# Altium schematic sheet MICROPHONE.SchDoc
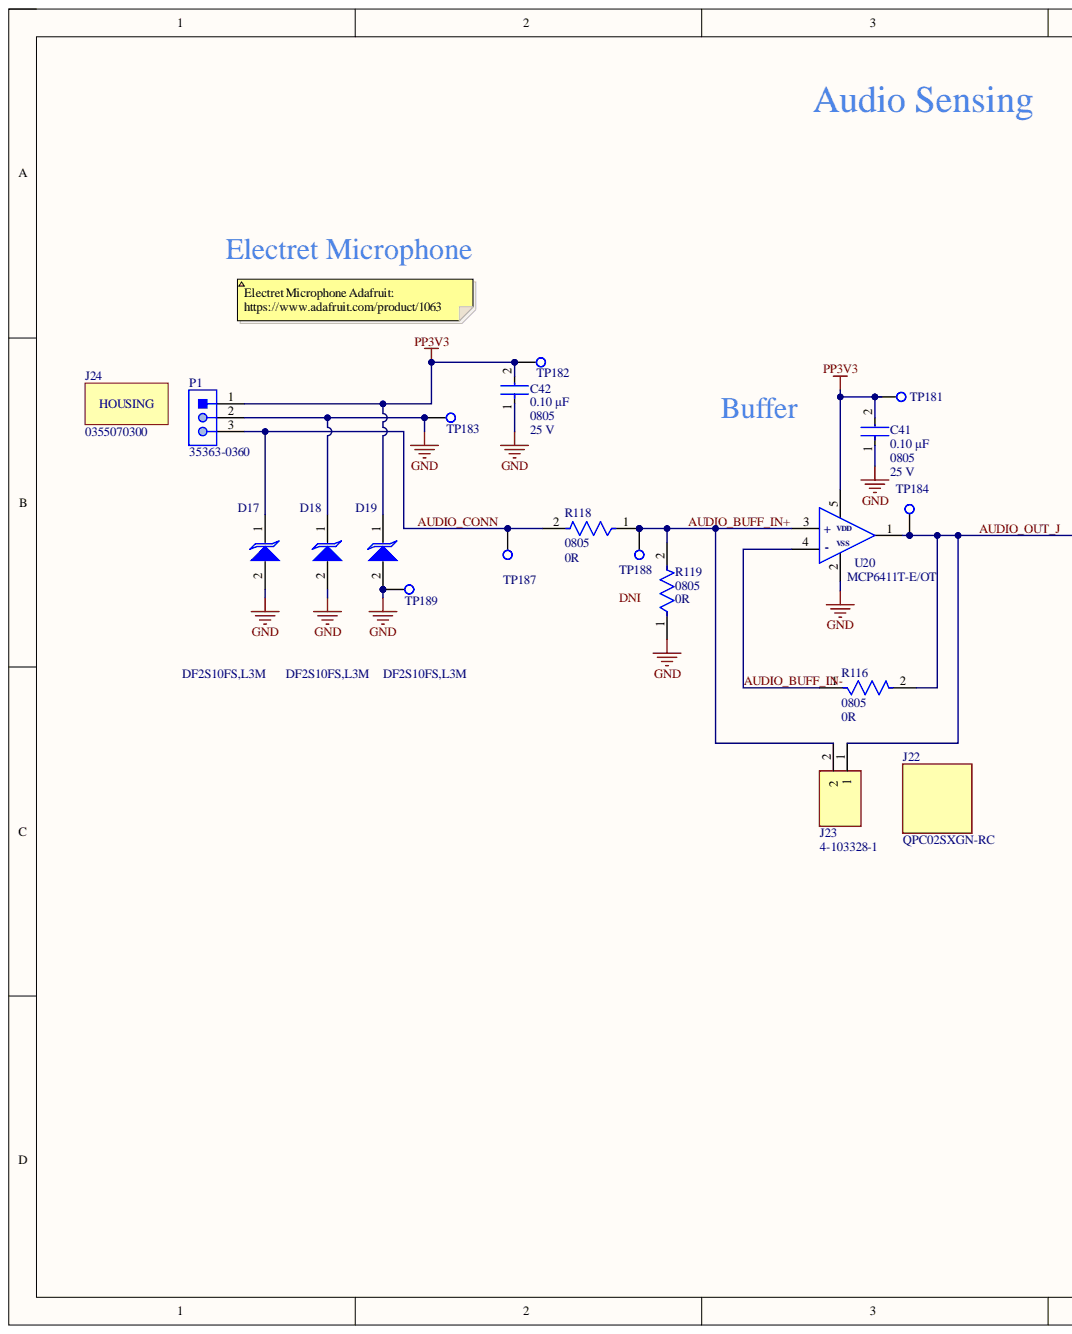
[diagram p0: MICROPHONE.SchDoc, left]
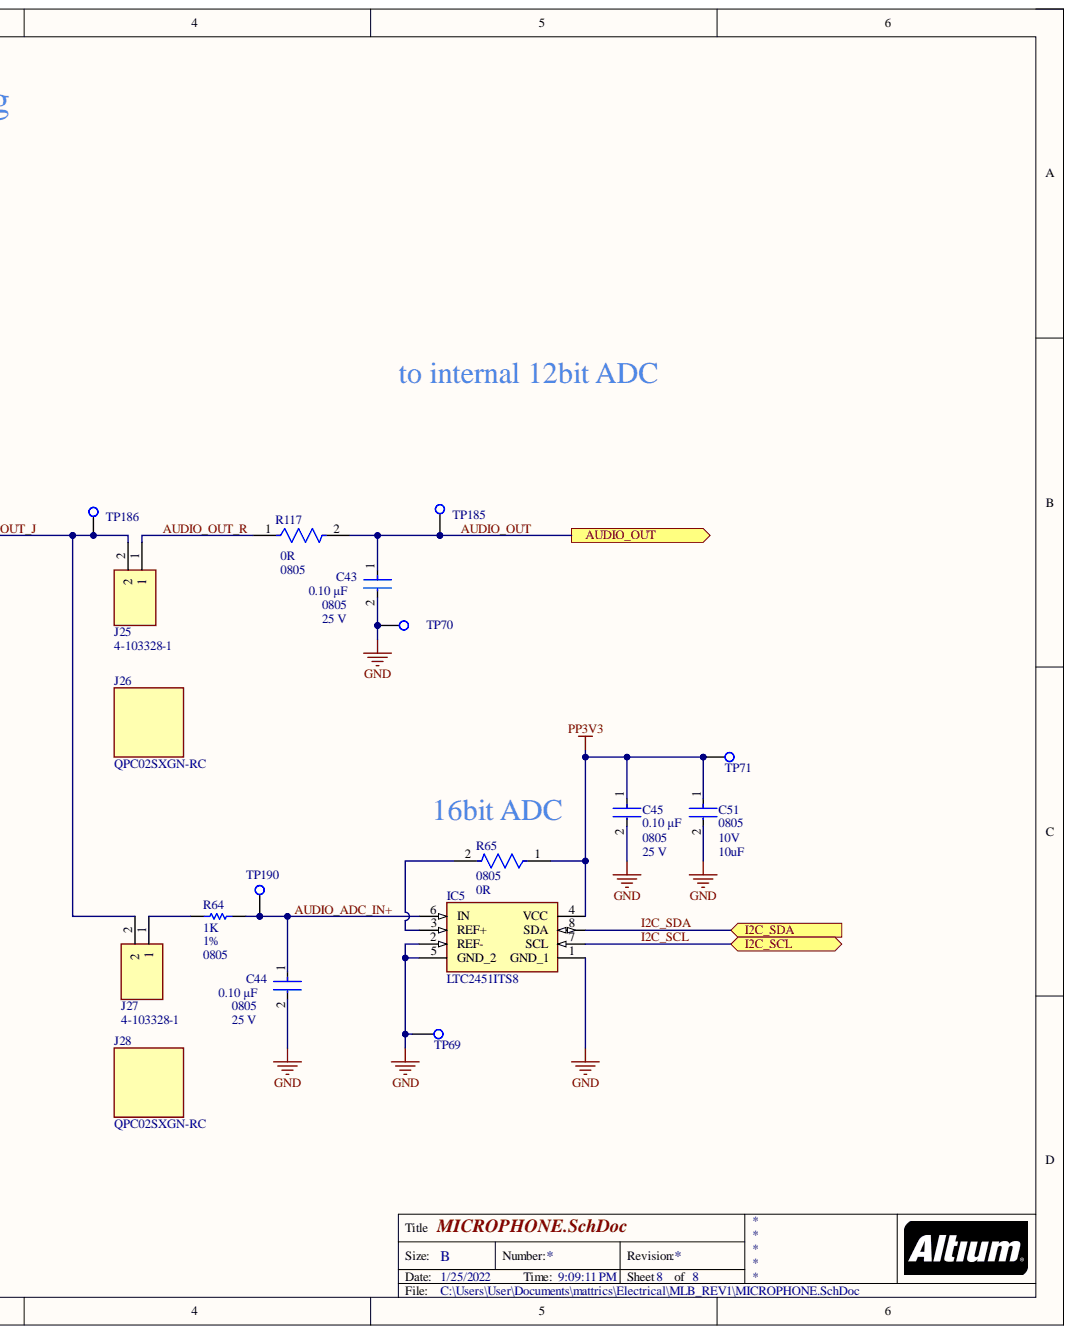
[diagram p1: MICROPHONE.SchDoc, right]

## Parts
C41  value 0.10 μF  Capacitance: 0.10 μF  Package: 0805  [FP-C0805C-DN-MFG]  (hidden comment: C0805C104M3RACTU)
C42  value 0.10 μF  Capacitance: 0.10 μF  Package: 0805  Voltage Rating: 25 V  [FP-C0805C-DN-MFG]  (hidden comment: C0805C104M3RACTU)
C43  value 0.10 μF  Capacitance: 0.10 μF  Package: 0805  Voltage Rating: 25 V  [FP-C0805C-DN-MFG]  (hidden comment: C0805C104M3RACTU)
C44  value 0.10 μF  Package: 0805  Voltage Rating: 25 V  [FP-C0805C-DN-MFG]  (hidden comment: C0805C104M3RACTU)
C45  value 0.10 μF  Capacitance: 0.10 μF  Package: 0805  Voltage Rating: 25 V  [FP-C0805C-DN-MFG]  (hidden comment: C0805C104M3RACTU)
C51  Capacitance: 10uF  Case/Package: 0805  Voltage Rating (DC): 10V  [FP-GRM21B-0_15-IPC_A]  (hidden comment: GRM21BR71A106KA73K)
D17  DF2S10FS,L3M  [DIOM106X45N]
D18  DF2S10FS,L3M  [DIOM106X45N]
D19  DF2S10FS,L3M  [DIOM106X45N]
IC5  LTC2451ITS8  [SOT65P280X100-8N]
J22  QPC02SXGN-RC  [FP-QPC02SXGN-RC-MFG]
J23  4-103328-1  [TE_4-103328-1]
J24  0355070300
J25  4-103328-1  [TE_4-103328-1]
J26  QPC02SXGN-RC  [FP-QPC02SXGN-RC-MFG]
J27  4-103328-1  [TE_4-103328-1]
J28  QPC02SXGN-RC  [FP-QPC02SXGN-RC-MFG]
P1  35363-0360  [MOLX-35363-0360_V]
R64  Case Code (Imperial): 0805  Resistance: 1K  Tolerance: 1%  [RESC2013X60X35ML10T20]  (hidden comment: RC0805FR-071KL)
R65  Case Code (Imperial): 0805  [FP-RMCF0805-MFG]  (hidden comment: RMCF0805ZT0R00)
R116  Case Code (Imperial): 0805  Resistance: 0R  [FP-RMCF0805-MFG]  (hidden comment: RMCF0805ZT0R00)
R117  Case Code (Imperial): 0805  Resistance: 0R  [FP-RMCF0805-MFG]  (hidden comment: RMCF0805ZT0R00)
R118  Case Code (Imperial): 0805  Resistance: 0R  [FP-RMCF0805-MFG]  (hidden comment: RMCF0805ZT0R00)
R119  Case Code (Imperial): 0805  Resistance: 0R  [FP-RMCF0805-MFG]  (hidden comment: RMCF0805ZT0R00)
TP69  RCU-0C  [TP+195X110]  (value not drawn)
TP70  RCU-0C  [TP+195X110]  (value not drawn)
TP71  RCU-0C  [TP+195X110]  (value not drawn)
TP181  RCU-0C  [TP+195X110]  (value not drawn)
TP182  RCU-0C  [TP+195X110]  (value not drawn)
TP183  RCU-0C  [TP+195X110]  (value not drawn)
TP184  RCU-0C  [TP+195X110]  (value not drawn)
TP185  RCU-0C  [TP+195X110]  (value not drawn)
TP186  RCU-0C  [TP+195X110]  (value not drawn)
TP187  RCU-0C  [TP+195X110]  (value not drawn)
TP188  RCU-0C  [TP+195X110]  (value not drawn)
TP189  RCU-0C  [TP+195X110]  (value not drawn)
TP190  RCU-0C  [TP+195X110]  (value not drawn)
U20  MCP6411T-E/OT  [SOT95P280X145-5N]

## Ports
AUDIO_OUT, I2C_SCL, I2C_SDA

## Nets
GND: C41.1 C42.1 C43.2 C44.2 C45.2 C51.2 D17.2 D18.1 D18.2 D19.2 IC5.1(GND_1) IC5.2(REF-) IC5.5(GND_2) P1.2 R119.1 TP69.1 TP70.1 TP183.1 TP189.1 U20.2
PP3V3: C41.2 C42.2 C45.1 C51.1 D19.1 IC5.4(VCC) P1.1 R65.1 TP71.1 TP181.1 TP182.1 U20.5
AUDIO_ADC_IN+: C44.1 IC5.6(IN) R64.1 TP190.1
AUDIO_BUFF_IN+: J23.2 R118.1 R119.2 TP188.1 U20.3(+)
AUDIO_BUFF_IN-: R116.1 U20.4(-)
AUDIO_CONN: D17.1 P1.3 R118.2 TP187.1
AUDIO_OUT: C43.1 R117.2 TP185.1 ; port AUDIO_OUT
AUDIO_OUT_J: J23.1 J25.2 J27.2 R116.2 TP184.1 TP186.1 U20.1
AUDIO_OUT_R: J25.1 R117.1
I2C_SCL: IC5.7(SCL) ; port I2C_SCL
I2C_SDA: IC5.8(SDA) ; port I2C_SDA
NetIC5_3: IC5.3(REF+) R65.2
NetJ27_1: J27.1 R64.2

## Library data of the parts
C41-C45 = CMP-2007-00282-2: CAP CER 0.1UF 25V 20% X7R 0805; Manufacturer: KEMET; Material: Ceramic; Temperature Coefficient: 30ppm/°C; Tolerance: 0.2; Automotive: No; Category: Cap; Component Kind: Standard; ComponentLink2Description: Datasheet; ComponentLink2URL: https://content.kemet.com/datasheets/KEM_C1003_C0G_SMD.pdf; Device Class L1: Passive Components; Device Class L2: Capacitors; Device Class L3: Ceramic Capacitors; Digikey Description: CAP CER 0.1UF 25V 20% X7R 0805; Height: 0.88mm; Ipc Land Pattern Name: CAPC200125X78; Lead Free: Yes; Library Name: Altium Content Vault; Manufacturer Part Number: C0805C104M3RACTU; Mouser Description: Multilayer Ceramic Capacitors MLCC - SMD/SMT 25volts 0.1uF X7R 20%; Octopart: https://octopart.com/c0805c104m3ractu-kemet-9138612; RoHS: TRUE; Supplier: Digi-Key; Supplier Part Number: 399-C0805C104M3RAC7800CT-ND; Temperature: -55°C to +125°C; Temperature Characteristic: X7R; Temperature Range High: +125°C; Temperature Range Low: -55°C
  C41: Voltage Rating: 25 V
  C44: Capacitance: 0.10 μF
C51 = CMP-06035-038134-1: Chip Multilayer Ceramic Capacitors for General Purpose, 0805, 10uF, X7R, 15%, 10%, 10V; Manufacturer: Murata Electronics; Component Kind: Standard; Dielectric: X7R; Length: 2mm; Library Name: Altium Content Vault; Manufacturer Part Number: GRM21BR71A106KA73K; Supplier: Digi-Key; Supplier Part Number: 490-14381-1-ND; Thickness: 1.25mm; Tolerance: 10%; Width: 1.25mm
D17-D19 = DF2S10FS,L3M: TVS DIODE 8VWM FSC; Manufacturer: Toshiba Semiconductor and Storage; Manufacturer_Name: Toshiba; Arrow Part Number: DF2S10FS,L3M; Arrow Price/Stock: https://www.arrow.com/en/products/df2s10fsl3m/toshiba?region=nac; Component Kind: Standard; Datasheet Link: https://componentsearchengine.com/Datasheets/1/DF2S10FS,L3M.pdf; Height: 0.45mm; Library Name: MLBSchLib.SchLib; Manufacturer Part Number: DF2S10FS,L3M; Manufacturer_Part_Number: DF2S10FS,L3M; Mouser Part Number: 757-DF2S10FSL3M; Mouser Price/Stock: https://www.mouser.co.uk/ProductDetail/Toshiba/DF2S10FSL3M?qs=lhkzy8lC%252BSsM5fAm2E7mCQ%3D%3D; Supplier: Digi-Key; Supplier Part Number: DF2S10FSL3MCT-ND
IC5 = LTC2451ITS8#TRMPBF: IC ADC 16BIT SIG-DELTA TSOT23-8; Manufacturer: Analog Devices Inc.; Manufacturer Part Number: LTC2451ITS8#TRPBF; Arrow Part Number: LTC2451ITS8#TRMPBF; Arrow Price/Stock: https://www.arrow.com/en/products/ltc2451its8trmpbf/linear-technology; Component Kind: Standard; Datasheet Link: http://www.farnell.com/datasheets/1705581.pdf?_ga=2.21625278.449712991.1504041739-494487131.1503310922; Height: 1mm; Library Name: MLBSchLib.SchLib; Mouser Part Number: 584-C2451ITS8TRMPBF; Mouser Price/Stock: https://www.mouser.com/Search/Refine.aspx?Keyword=584-C2451ITS8TRMPBF; Supplier: Digi-Key; Supplier Part Number: 505-LTC2451ITS8#TRPBFCT-ND
J22 J26 J28 = CMP-25666-000001-1: CONN JUMPER SHORTING .100" GOLD; Manufacturer: Sullins; Conn Orientation: Straight; Current Rating: 3A; Number Of Contacts: 2; Pitch: 2.54mm; Automotive: No; Category: Conn; Component Kind: Standard; ComponentLink2Description: Datasheet; ComponentLink2URL: https://s3.amazonaws.com/catalogspreads-pdf/PAGE128-129%20.100%20JUMPER.pdf; Contact Material: Gold; Device Class L1: Connectors; Device Class L2: unset; Device Class L3: unset; Digikey Description: CONN JUMPER SHORTING .100" GOLD; Footprint Url: https://drawings-pdf.s3.amazonaws.com/11510.pdf; Height: 6.17mm; Lead Free: Yes; Library Name: Altium Content Vault; Manufacturer Part Number: QPC02SXGN-RC; Octopart: https://octopart.com/qpc02sxgn-rc-sullins-19878795; Package: 0; RoHS: TRUE; Supplier: Digi-Key; Supplier Part Number: S9337-ND; Temperature: -40°C to +85°C; Temperature Range High: +85°C; Temperature Range Low: -40°C
J23 J25 J27 = 4-103328-1: Connector Header Through Hole 2 position; Manufacturer: TE Connectivity AMP Connectors; MF: TE Connectivity; Availability: Unavailable; Component Kind: Standard; EU_RoHS_Compliance: Compliant; Library Name: MLBSchLib.SchLib; Manufacturer Part Number: 4-103328-1; MP: 4-103328-1; Package: None; Price: None; SnapEDA_Link: https://www.snapeda.com/parts/4-103328-1/TE%20Connectivity%20AMP%20Connectors/view-part/2384420/?ref=snap; Supplier: Digi-Key; Supplier Part Number: A121364-ND; TE_purchase_URL: https://www.te.com/usa-en/product-4-103328-1.html?te_bu=Cor&te_type=disp&te_campaign=seda_glo_cor-seda-global-disp-prtnr-fy19-seda-model-bom-cta_sma-317_1&elqCampaignId=32493
J24 = 0355070300: Manufacturer: Molex; Component Kind: Standard; Library Name: MLBSchLib.SchLib; Manufacturer Part Number: 0355070300; Supplier: Digi-Key; Supplier Part Number: WM5982-ND
P1 = CMP-2000-05595-1: Male Header, Pitch 2 mm, 1 x 3 Position, Height 5.5 mm, Tail Length 3.2 mm, -40 to 105 degC, RoHS, Bulk; Manufacturer: Molex; Manufacturer Part Number: 0353630360; Gender: HDR; Number of Contacts: 3; Pitch: 2mm; Category: ConnectorHeadersandPCBReceptacles; Component Kind: Standard; Datasheet URL: http://www.molex.com/pdm_docs/sd/353630360_sd.pdf; Datasheet Version: Rev. G, 01/2012; Library Name: Altium Content Vault; Manufacturer URL: http://www.molex.com/; Mounting Technology: ThroughHole; Number of Rows: 1; Package Description: Male Header, Pitch 2 mm, 1 x 3 Position, Height 5.5 mm, Tail Length 3.2 mm; Package Reference: 35363-0360; Supplier: Digi-Key; Supplier Part Number: WM18932-ND; Termination Method: Solder; Type: WiretoBoard
R64 = CMP-2100-03671-1: Chip Resistor, 1 KOhm, +/- 1%, 125 mW, -55 to 155 degC, 0805 (2012 Metric), RoHS, Tape and Reel; Manufacturer: Yageo; Max Power Dissipation: 125mW; Temperature Coefficient: 100ppm/degreesC; Voltage Rating: 150V; Case Code (Metric): 2012; Case/Package: 0805; Component Kind: Standard; Composition: ThickFilm; Datasheet URL: http://www.yageo.com/NewPortal/yageodocoutput?fileName=/pdf/R-Chip/PYu-RC_Group_51_RoHS_L_5.pdf; Datasheet Version: Rev. 5, 10/2016; Depth: 1.25mm; Height: 0.024inch; Lead Free: LeadFree; Length: 0.079inch; Library Name: Altium Content Vault; Manufacturer Part Number: RC0805FR-071KL; Manufacturer URL: http://www.yageo.com/; Max Operating Temperature: 155degC; Military Standard: Not; Min Operating Temperature: -55degC; Number of Pins: 2; Number of Terminations: 2; Package Description: 2-Pin Surface Mounted Device, Body 2 x 1.25 mm; Package Quantity: 1; Package Reference: 0805; Packaging: TapeandReel; Power Rating: 125mW; REACH SVHC: Unknown; RoHSCompliant: true; Supplier: Digi-Key; Supplier Part Number: 311-1.00KCRCT-ND; Termination: SMD/SMT; Voltage Rating (DC): 150V; Width: 0.049inch
R65 = CMP-26527-000017-1: RES 0 OHM JUMPER 1/8W 0805; Manufacturer: Stackpole Electronics Inc; Max Power Dissipation: 125mW; Resistance: 0R; Case Code (Metric): 2012; Case/Package: 0805; Component Kind: Standard; Composition: Thick Film; Contact Plating: Tin; Depth: 1.25mm; Features: Automotive AEC-Q200; Height: 0.026inch; Lead Free: Lead Free; Length: 2mm; Library Name: Altium Content Vault; Manufacturer Part Number: RMCF0805ZT0R00; Max Operating Temperature: 155°C; Military Standard: Not; Min Operating Temperature: -55°C; Mount: Surface Mount; Number of Terminations: 2; Packaging: Tape and Reel; Power Rating: 125mW; Radiation Hardening: No; Supplier: Digi-Key; Supplier Part Number: RMCF0805ZT0R00CT-ND; Width: 0.049inch
R116-R119 = CMP-26527-000017-1: RES 0 OHM JUMPER 1/8W 0805; Manufacturer: Stackpole Electronics Inc; Max Power Dissipation: 125mW; Case Code (Metric): 2012; Case/Package: 0805; Component Kind: Standard; Composition: Thick Film; Contact Plating: Tin; Depth: 1.25mm; Features: Automotive AEC-Q200; Height: 0.026inch; Lead Free: Lead Free; Length: 2mm; Library Name: Altium Content Vault; Manufacturer Part Number: RMCF0805ZT0R00; Max Operating Temperature: 155°C; Military Standard: Not; Min Operating Temperature: -55°C; Mount: Surface Mount; Number of Terminations: 2; Packaging: Tape and Reel; Power Rating: 125mW; Radiation Hardening: No; Supplier: Digi-Key; Supplier Part Number: RMCF0805ZT0R00CT-ND; Width: 0.049inch
TP69-TP71 TP181-TP190 = RCU-0C: Natural PC Test Point , Plating Surface Mount Mounting Type; Manufacturer: TE Connectivity AMP Connectors; Check_prices: https://www.snapeda.com/parts/RCU-0C/TE%20Connectivity/view-part/4791537/?ref=eda; Component Kind: Standard; Library Name: MLBSchLib.SchLib; Manufacturer Part Number: RCU-0C; Package: SON-6 TE Connectivity AMP Connectors; Purchase-URL: https://pricing.snapeda.com/search/part/RCU-0C/?ref=eda; SnapEDA_Link: https://www.snapeda.com/parts/RCU-0C/TE%20Connectivity/view-part/4791537/?ref=snap; Supplier: Digi-Key; Supplier Part Number: A106145CT-ND
U20 = MCP6411T-E_OT: IC OPAMP GP 1 CIRCUIT SOT23-5; Manufacturer: Microchip; Arrow Part Number: MCP6411T-E/OT; Arrow Price/Stock: https://www.arrow.com/en/products/mcp6411t-eot/microchip-technology; Component Kind: Standard; Datasheet Link: http://ww1.microchip.com/downloads/en/DeviceDoc/20005791B.pdf; Height: 1.45mm; Library Name: MLBSchLib.SchLib; Manufacturer Part Number: MCP6411T-E/OT; Mouser Part Number: 579-MCP6411T-E/OT; Mouser Price/Stock: https://www.mouser.com/Search/Refine.aspx?Keyword=579-MCP6411T-E%2FOT; Supplier: Digi-Key; Supplier Part Number: MCP6411T-E/OTCT-ND
